# Altium schematic sheet module_rev2.SchDoc(module_rev2)
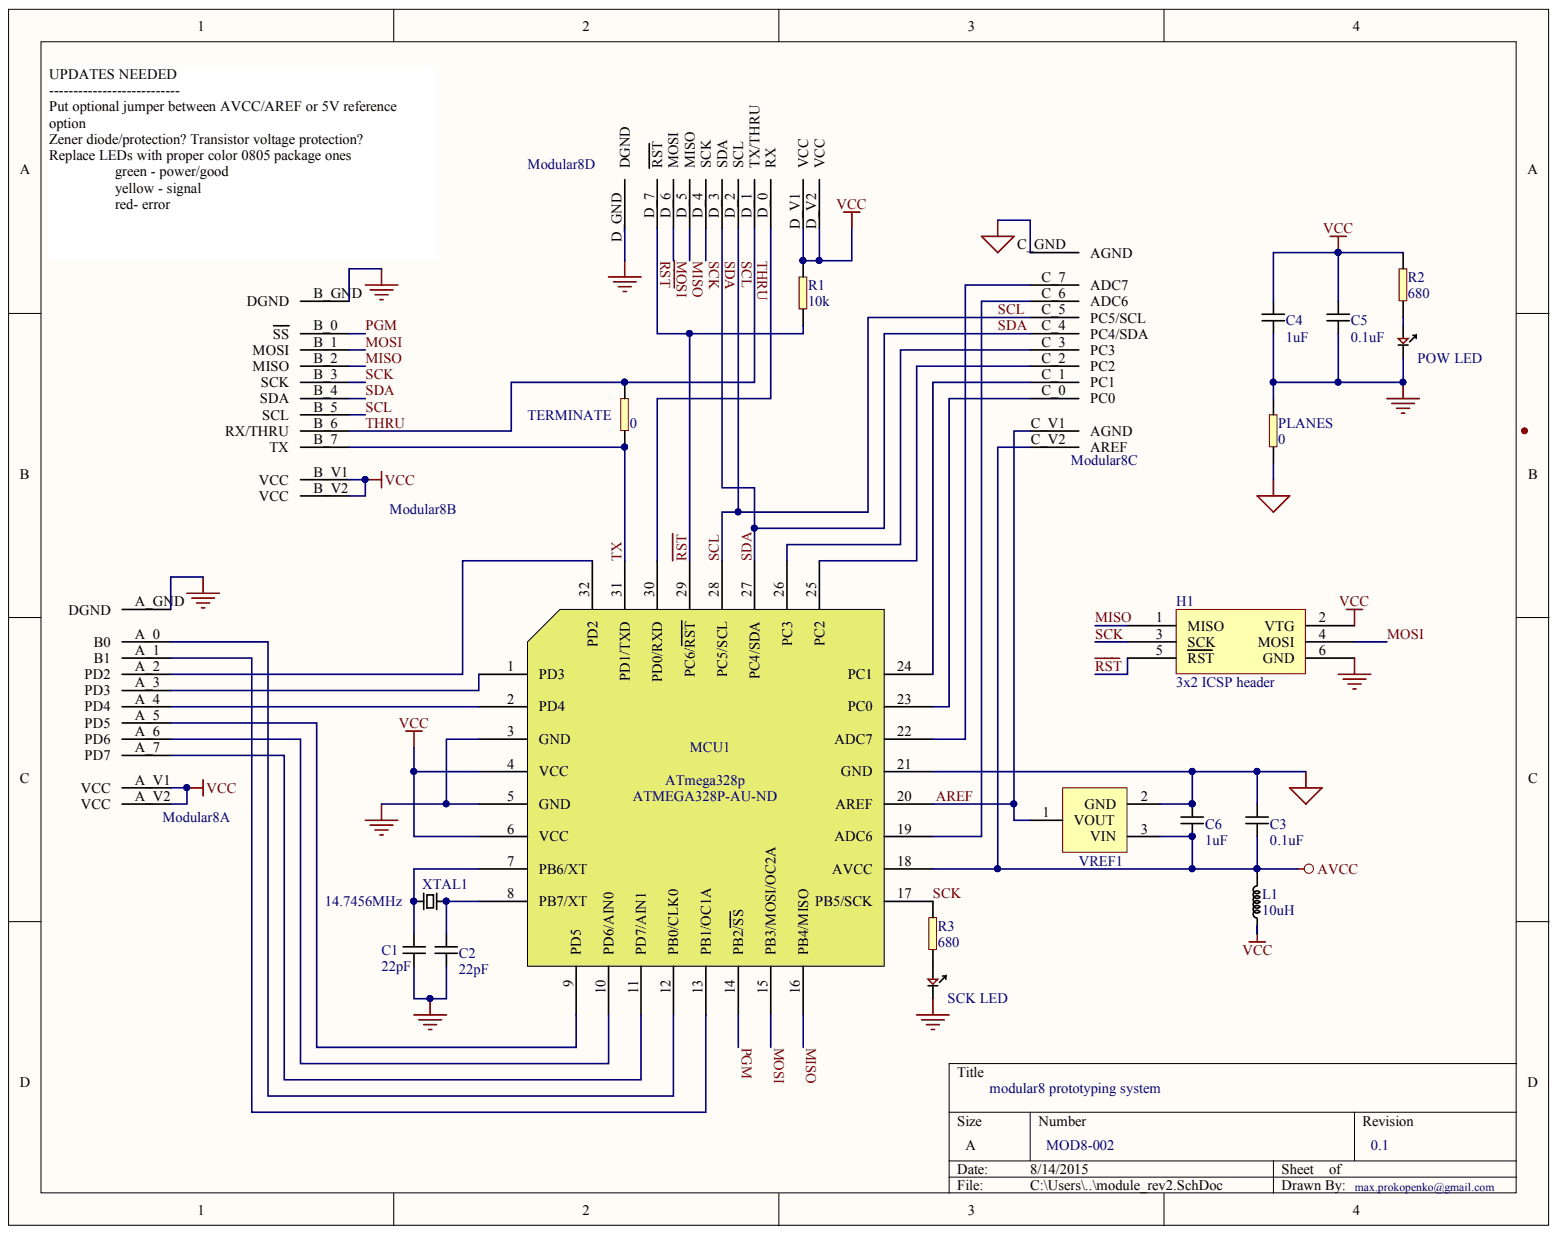
[diagram p0: module_rev2.SchDoc]

## Parts
C1  C: 22pF  [0603]  (hidden comment: C 22pF 25V)
C2  C: 22pF  [0603]  (hidden comment: C 22pF 25V)
C3  C: 0.1uF  [0603]  (hidden comment: C 0.1uF 25V)
C4  C: 1uF  [0603]  (hidden comment: C 1uF 25V)
C5  C: 0.1uF  [0603]  (hidden comment: C 0.1uF 25V)
C6  C: 1uF  [0603]  (hidden comment: C 1uF 25V)
H1  3x2 ICSP header  [3x2 THRU HOLES 0.1" PITCH]
L1  L: 10uH  [0603]  (hidden comment: L 10uH)
MCU1  ATmega328p  Supplier Part Number 1: ATMEGA328P-AU-ND  [ATmega328p TQFP]
Modular8  modular8_rev2  [modular8 metric]  (value not drawn)  (parts A,B,C,D)
PLANES  R 0  [0603]  (value not drawn)
POW_LED  LEDG  [0805 - LED]  (value not drawn)
R1  R: 10k  [0603]  (hidden comment: R 10k)
R2  R 680  [0603]  (value not drawn)
R3  R 680  [0603]  (value not drawn)
SCK_LED  LEDG  [0805 - LED]  (value not drawn)
TERMINATE  R 0  [0603]  (value not drawn)
VREF1  AD1584BRTZ  [SOT-23-3]  (value not drawn)
XTAL1  Frequency: 14.7456MHz  [XTAL CSM-3X]  (hidden comment: XTAL 14.7456MHz)

## Nets
AGND: C3.1 C6.1 MCU1.21(GND) Modular8.C_GND PLANES.1 VREF1.2
AVCC: C3.2 C6.2 L1.1 MCU1.18(AVCC) Modular8.C_V2 VREF1.3
DGND: C1.1 C2.2 C4.2 C5.2 H1.6(GND) MCU1.3(GND) MCU1.5(GND) Modular8.A_GND Modular8.B_GND Modular8.D_GND PLANES.2 POW_LED.2 SCK_LED.2
VCC: C4.1 C5.1 H1.2(VTG) L1.2 MCU1.4(VCC) MCU1.6(VCC) Modular8.A_V1 Modular8.A_V2 Modular8.B_V1 Modular8.B_V2 Modular8.D_V1 Modular8.D_V2 R1.1 R2.1
AREF: MCU1.20(AREF) Modular8.C_V1 VREF1.1
MISO: H1.1(MISO) MCU1.16(PB4/MISO) Modular8.B_2 Modular8.D_5
MOSI: H1.4(MOSI) MCU1.15(PB3/MOSI/OC2A) Modular8.B_1 Modular8.D_6
PGM: MCU1.14(PB2/SS) Modular8.B_0
~{RST}: H1.5(RST) MCU1.29(PC6/RST) Modular8.D_7 R1.2
SCK: H1.3(SCK) MCU1.17(PB5/SCK) Modular8.B_3 Modular8.D_4 R3.1
SCL: MCU1.28(PC5/SCL) Modular8.B_5 Modular8.C_5 Modular8.D_2
SDA: MCU1.27(PC4/SDA) Modular8.B_4 Modular8.C_4 Modular8.D_3
THRU: Modular8.B_6 Modular8.D_1 TERMINATE.1
TX: MCU1.31(PD1/TXD) Modular8.B_7 TERMINATE.2
NetC1_2: C1.2 MCU1.7(PB6/XT) XTAL1.1
NetC2_1: C2.1 MCU1.8(PB7/XT) XTAL1.2
NetMCU1_1: MCU1.1(PD3) Modular8.A_3
NetMCU1_2: MCU1.2(PD4) Modular8.A_4
NetMCU1_9: MCU1.9(PD5) Modular8.A_5
NetMCU1_10: MCU1.10(PD6/AIN0) Modular8.A_6
NetMCU1_11: MCU1.11(PD7/AIN1) Modular8.A_7
NetMCU1_12: MCU1.12(PB0/CLK0) Modular8.A_0
NetMCU1_13: MCU1.13(PB1/OC1A) Modular8.A_1
NetMCU1_19: MCU1.19(ADC6) Modular8.C_6
NetMCU1_22: MCU1.22(ADC7) Modular8.C_7
NetMCU1_23: MCU1.23(PC0) Modular8.C_0
NetMCU1_24: MCU1.24(PC1) Modular8.C_1
NetMCU1_25: MCU1.25(PC2) Modular8.C_2
NetMCU1_26: MCU1.26(PC3) Modular8.C_3
NetMCU1_30: MCU1.30(PD0/RXD) Modular8.D_0
NetMCU1_32: MCU1.32(PD2) Modular8.A_2
NetPOW LED_1: POW_LED.1 R2.2
NetR3_2: R3.2 SCK_LED.1

## Library data of the parts
PLANES TERMINATE = R 0: R: 0; Component Kind: Standard; Library Name: RLC.SchLib; Supplier 1: Digi-Key; Supplier Part Number 1: RHM0.0GCT-ND
R2 R3 = R 680: R: 680; Component Kind: Standard; Library Name: RLC.SchLib; Supplier 1: Digi-Key; Supplier Part Number 1: 311-680GRCT-ND
VREF1 = AD1584BRTZ: V: 4.096V; Component Kind: Standard; Supplier 1: Digi-Key; Supplier Part Number 1: AD1584BRTZ-REEL7CT-ND; Library Name: OpBots.SchLib
C1 C2 = C 22pF 25V: Component Kind: Standard; Library Name: RLC.SchLib; Supplier 1: Digi-Key; Supplier Part Number 1: 445-1273-1-ND
C3 C5 = C 0.1uF 25V: Component Kind: Standard; Library Name: RLC.SchLib; Supplier 1: Digi-Key; Supplier Part Number 1: 445-1316-1-ND
C4 C6 = C 1uF 25V: Component Kind: Standard; Library Name: RLC.SchLib; Supplier 1: Digi-Key; Supplier Part Number 1: 1276-1858-1-ND
H1 = 3x2 ICSP header: Component Kind: Standard; Supplier 1: Digi-Key; Supplier Part Number 1: 609-3234-ND; Library Name: OpBots.SchLib
L1 = L 10uH: Component Kind: Standard; Library Name: RLC.SchLib; Supplier 1: Digi-Key; Supplier Part Number 1: 490-4025-1-ND
MCU1 = ATmega328p: Component Kind: Standard; Supplier 1: Digi-Key; Library Name: OpBots.SchLib
Modular8 = modular8_rev2: Component Kind: Standard; Library Name: OpBots.SchLib
POW_LED SCK_LED = LEDG: Component Kind: Standard; Supplier 1: Digi-Key; Supplier Part Number 1: 160-1423-1-ND; Library Name: OpBots.SchLib
R1 = R 10k: Component Kind: Standard; Library Name: RLC.SchLib; Supplier 1: Digi-Key; Supplier Part Number 1: 311-10KGRCT-ND
XTAL1 = XTAL 14.7456MHz: Component Kind: Standard; Supplier 1: Digi-Key; Supplier Part Number 1: XC1775CT-ND; Library Name: OpBots.SchLib
